# Altium schematic sheet RGBLED.SchDoc
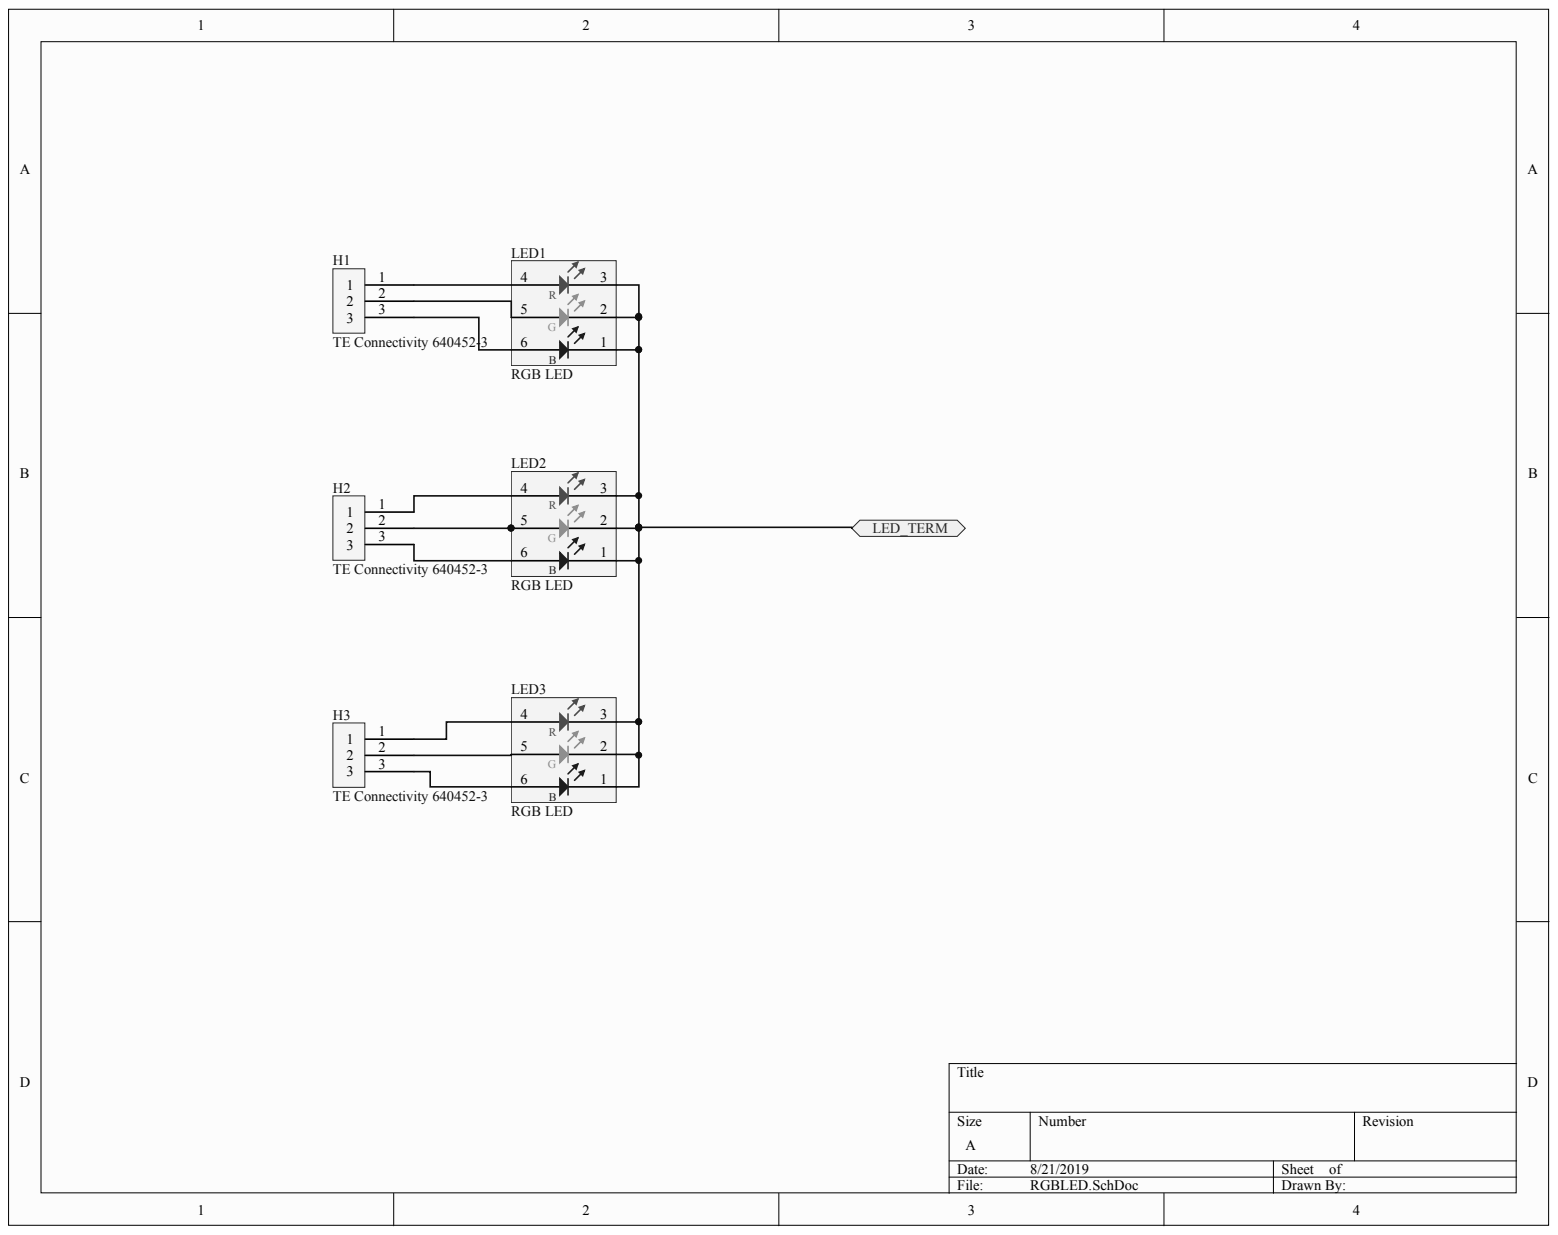
[diagram p0: RGBLED.SchDoc]

## Parts
H1  TE Connectivity 640452-3  Manufacturer Part Number: 640452-3  [PCB-490ps82ehmt4usn7lp7z-1]
H2  TE Connectivity 640452-3  Manufacturer Part Number: 640452-3  [PCB-490ps82ehmt4usn7lp7z-1]
H3  TE Connectivity 640452-3  Manufacturer Part Number: 640452-3  [PCB-490ps82ehmt4usn7lp7z-1]
LED1  RGB LED  [PCB-2qekydhcebyyg3v1eiru-1]
LED2  RGB LED  [PCB-2qekydhcebyyg3v1eiru-1]
LED3  RGB LED  [PCB-2qekydhcebyyg3v1eiru-1]

## Ports
LED_TERM

## Nets
LED_TERM: LED1.1 LED1.2 LED1.3 LED2.1 LED2.2 LED2.3 LED3.1 LED3.2 LED3.3 ; port LED_TERM
NetH1_1: H1.1 LED1.4
NetH1_2: H1.2 LED1.5
NetH1_3: H1.3 LED1.6
NetH2_1: H2.1 LED2.4
NetH2_2: H2.2 LED2.5
NetH2_3: H2.3 LED2.6
NetH3_1: H3.1 LED3.4
NetH3_2: H3.2 LED3.5
NetH3_3: H3.3 LED3.6

## Library data of the parts
H1-H3 = CMP-be5d759206cb619a-1: MTA-100 3 Position 2.54 mm Single Row Through-Hole Straight Wire to Board Header; Current Rating: 5.00 A; Mounting Angle: 180 °; Number of Contacts: 3; Pin Pitch: 2.54 mm; Shrouded: No; Color: White; Component Kind: Standard; Library Name: CommunityVault; Number of Positions: 3; Orientation: Vertical; RoHS: Compliant; Size-Length: 7.62 mm; Size-Width: 5.08 mm
LED1-LED3 = CMP-40a28df2be6b9f65-1: Full Rank, Tape & Reel / 2800 Pcs/Reel; Manufacturer Part Number: CLX6F-FKC-CKNNQDGBB7A363; Component Kind: Standard; Library Name: CommunityVault; Octopart URL: http://octopart.com/clx6f-fkc-cknnqdgbb7a363-cree-73063343; RoHS: Non-Compliant
